# Altium schematic sheet Dev Board STM OLED 2.0.SchDoc("Dev Board STM OLED 2.0")
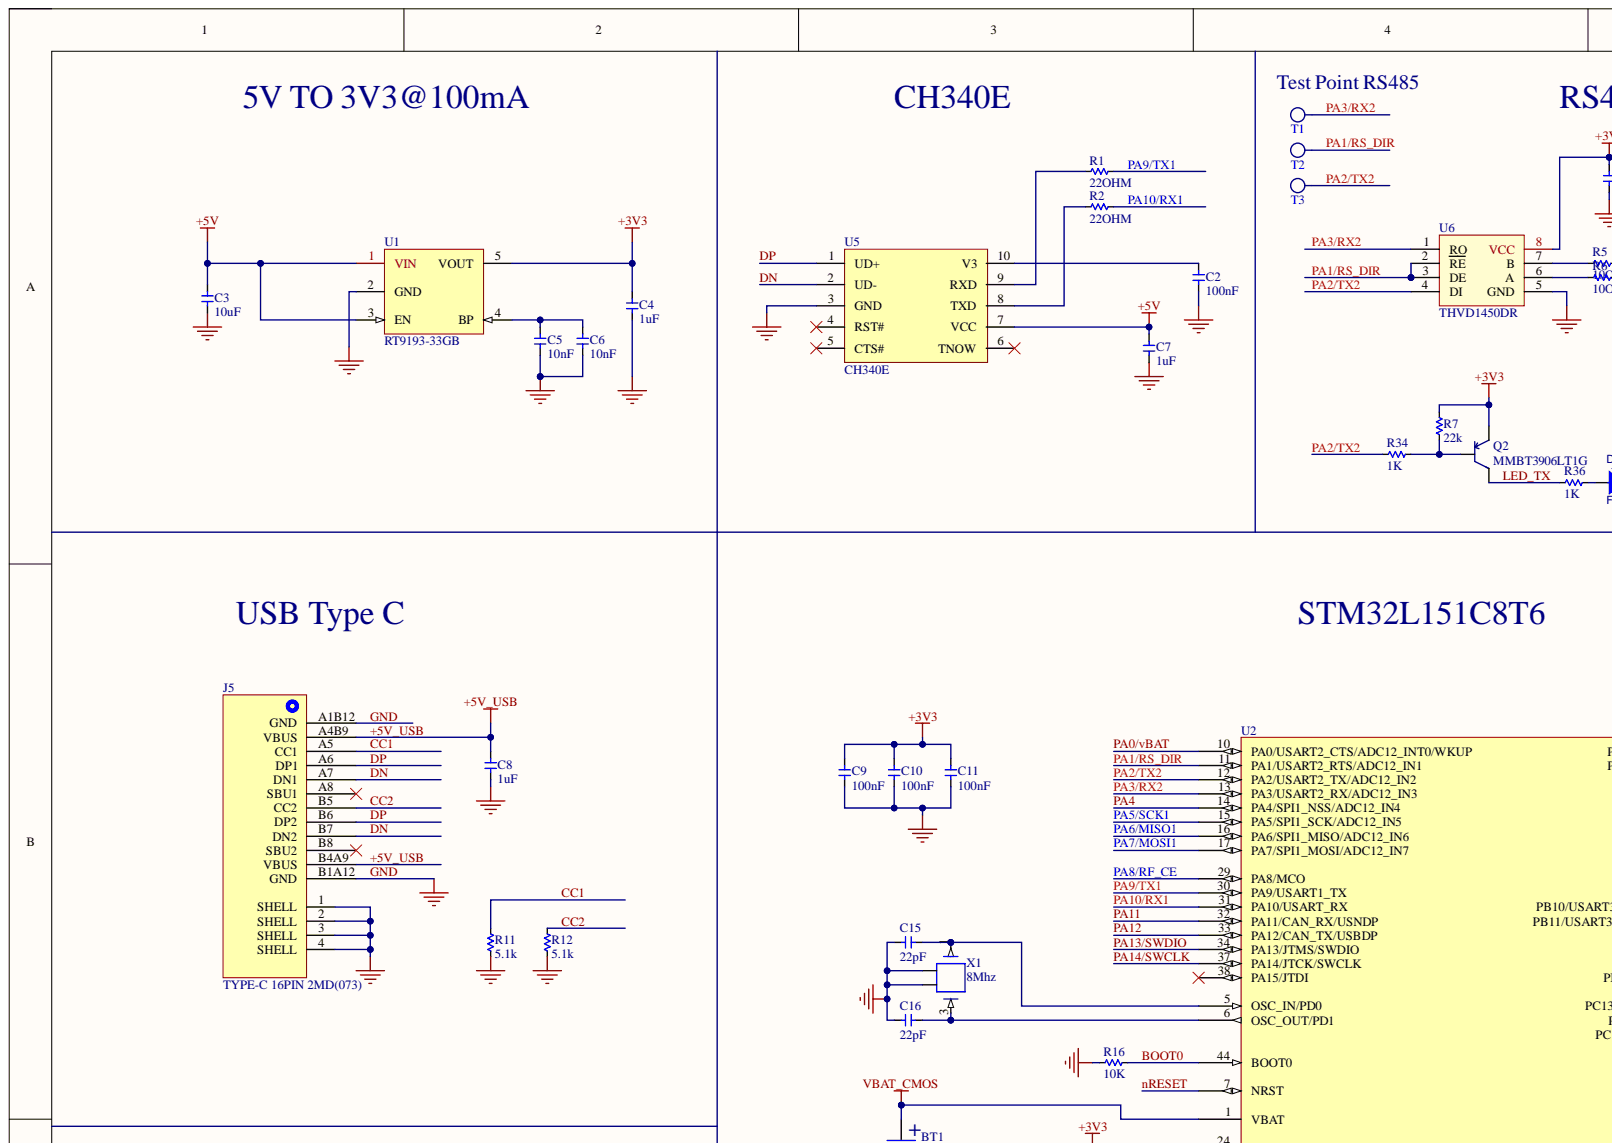
[diagram p0: Dev Board STM OLED 2.0.SchDoc, top left]
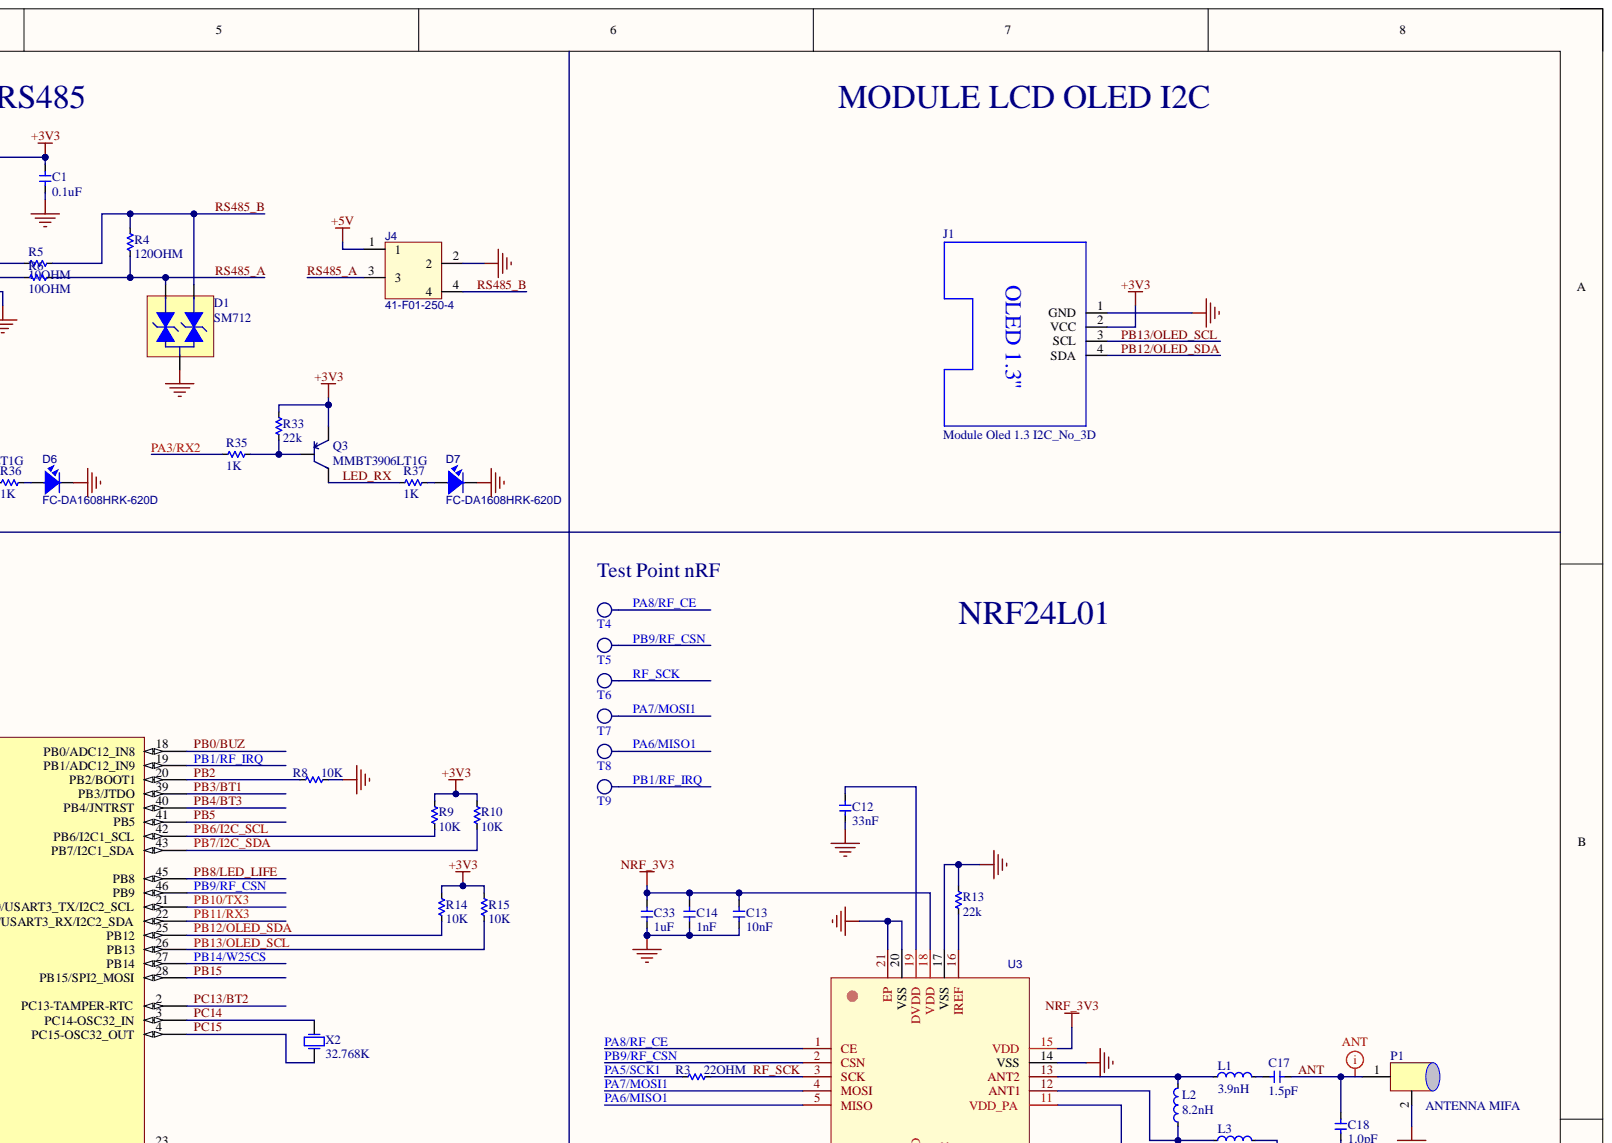
[diagram p1: Dev Board STM OLED 2.0.SchDoc, top right]
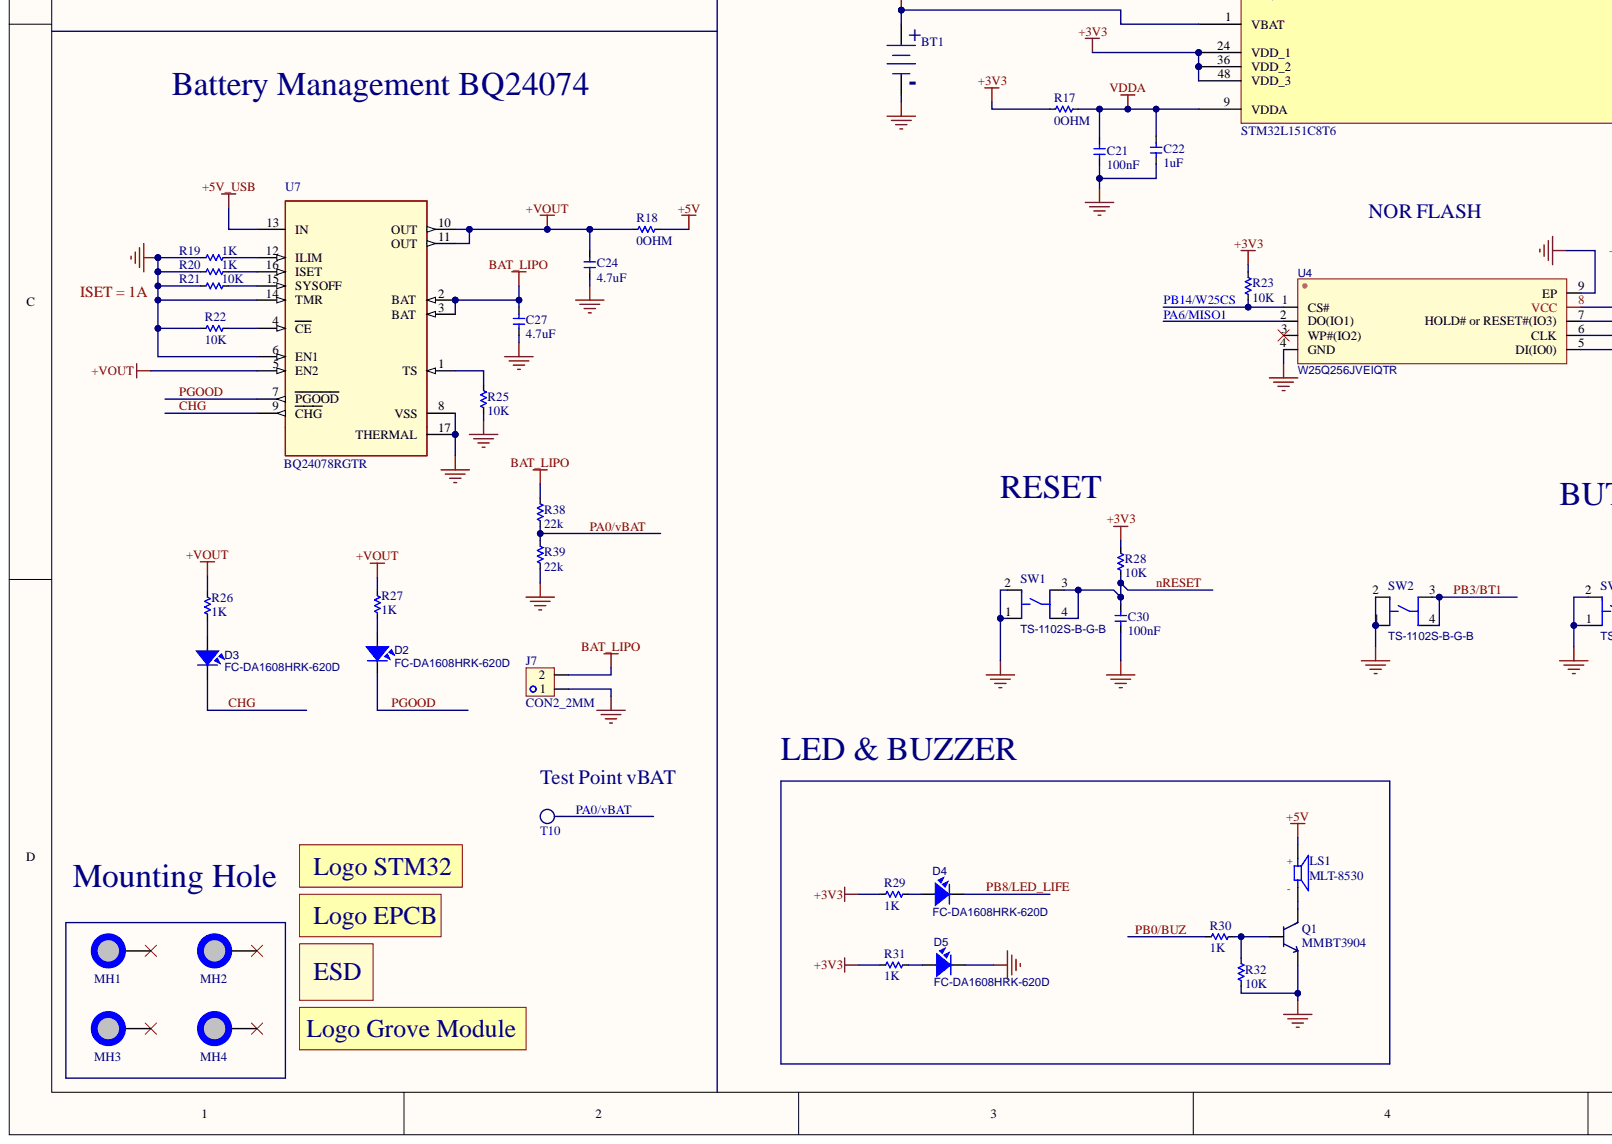
[diagram p2: Dev Board STM OLED 2.0.SchDoc, bottom left]
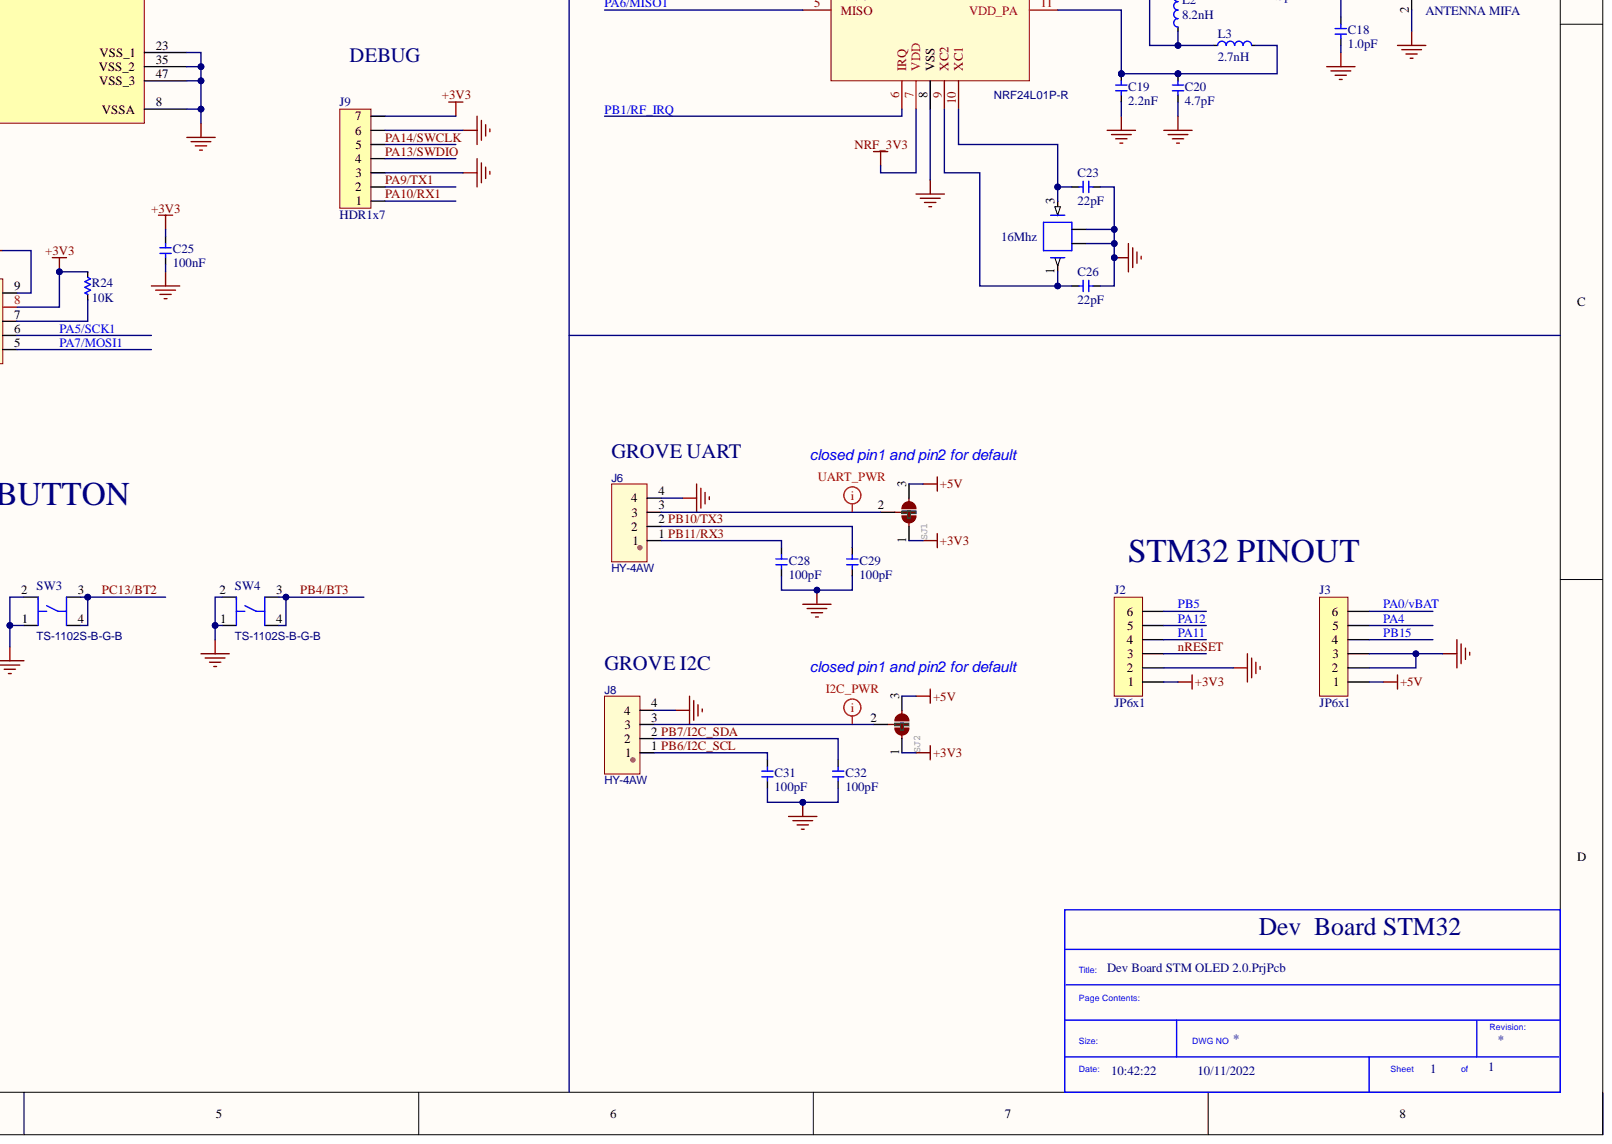
[diagram p3: Dev Board STM OLED 2.0.SchDoc, bottom right]

## Parts
BT1  CR1220-2ZX  [BAT_CR1220_SMD]  (value not drawn)
C1  0.1uF  [C_0603]
C2  100nF  [C_0402]
C3  10uF  [C_0805]
C4  1uF  [C_0603]
C5  10nF  [C_0402]
C6  10nF  [C_0402]
C7  1uF  [C_0603]
C8  1uF  [C_0603]
C9  100nF  [C_0402]
C10  100nF  [C_0402]
C11  100nF  [C_0402]
C12  33nF  [C_0402]
C13  10nF  [C_0402]
C14  1nF  [C_0402]
C15  22pF  [C_0402]
C16  22pF  [C_0402]
C17  1.5pF  [C_0402]
C18  1.0pF  [C_0402]
C19  2.2nF  [C_0402]
C20  4.7pF  [C_0402]
C21  100nF  [C_0402]
C22  1uF  [C_0603]
C23  22pF  [C_0402]
C24  4.7uF  [C_0603]
C25  100nF  [C_0402]
C26  22pF  [C_0402]
C27  4.7uF  [C_0603]
C28  100pF  [C_0402]
C29  100pF  [C_0402]
C30  100nF  [C_0402]
C31  100pF  [C_0402]
C32  100pF  [C_0402]
C33  1uF  [C_0603]
D1  SM712  [SOT-23-3_L2.9-W1.6-P1.90-LS2.8-BR]
D2  FC-DA1608HRK-620D  [LED0603-RD_RED]
D3  FC-DA1608HRK-620D  [LED0603-RD_RED]
D4  FC-DA1608HRK-620D  [LED0603-RD_RED]
D5  FC-DA1608HRK-620D  [LED0603-RD_RED]
D6  FC-DA1608HRK-620D  [LED0603-RD_RED]
D7  FC-DA1608HRK-620D  [LED0603-RD_RED]
ESD1  ESD  [LOGO ESD]  (no BOM)
J1  Module Oled 1.3 I2C_No_3D  [Module Oled 1.3 - No 3D]
J2  JP6x1  [JP6-D1T]  (no BOM)
J3  JP6x1  [JP6-D1T]  (no BOM)
J4  41-F01-250-4  [CONN-TH_41-F01-250-4]
J5  TYPE-C 16PIN 2MD(073)  [USB-C-SMD_TYPE-C16PIN]
J6  HY-4AW  [CONN-TH_4P-P2.00_HY-4AW]
J7  CON2_2MM  [CONN-TH_2P-P2.00_X2016WV-02K-N0SN]
J8  HY-4AW  [CONN-TH_4P-P2.00_HY-4AW]
J9  HDR1x7  [HDR1X7H]
L1  3.9nH  [L_0402]
L2  8.2nH  [L_0402]
L3  2.7nH  [L_0402]
LO1  Logo STM32  [LOGO STM32]  (no BOM)
LO2  LOGO EPCB  [LOGO EPCB TopLayer]  (value not drawn)  (no BOM)
LO3  Logo Grove Module  [Logo Grove Module]  (no BOM)
LS1  MLT-8530  [BUZ-SMD_4P-L8.5-W8.5-P8.50-BR]
MH1  MH_6.0MMC_3.2MMd_No Cu  [MH_6.0MMD_3.2MMD - No Cu]  (value not drawn)  (no BOM)
MH2  MH_6.0MMC_3.2MMd_No Cu  [MH_6.0MMD_3.2MMD - No Cu]  (value not drawn)  (no BOM)
MH3  MH_6.0MMC_3.2MMd_No Cu  [MH_6.0MMD_3.2MMD - No Cu]  (value not drawn)  (no BOM)
MH4  MH_6.0MMC_3.2MMd_No Cu  [MH_6.0MMD_3.2MMD - No Cu]  (value not drawn)  (no BOM)
P1  ANTENNA MIFA  [ANTENNA MIFA]  (no BOM)
Q1  MMBT3904  [SOT-23-3_L2.9-W1.3-P1.90-LS2.4-BR]
Q2  MMBT3906LT1G  [SOT-23-3_L2.9-W1.6-P1.90-LS2.8-BR]
Q3  MMBT3906LT1G  [SOT-23-3_L2.9-W1.6-P1.90-LS2.8-BR]
R1  22OHM  [R_0402]
R2  22OHM  [R_0402]
R3  22OHM  [R_0402]
R4  120OHM  [R_0603]
R5  10OHM  [R_0805]
R6  10OHM  [R_0805]
R7  22k  [R_0402]
R8  10K  [R_0402]
R9  10K  [R_0402]
R10  10K  [R_0402]
R11  5.1k  [R_0402]
R12  5.1k  [R_0402]
R13  22k  [R_0402]
R14  10K  [R_0402]
R15  10K  [R_0402]
R16  10K  [R_0402]
R17  0OHM  [R_0603]
R18  0OHM  [R_0603]
R19  1K  [R_0402]
R20  1K  [R_0402]
R21  10K  [R_0402]
R22  10K  [R_0402]
R23  10K  [R_0402]
R24  10K  [R_0402]
R25  10K  [R_0402]
R26  1K  [R_0402]
R27  1K  [R_0402]
R28  10K  [R_0402]
R29  1K  [R_0402]
R30  1K  [R_0402]
R31  1K  [R_0402]
R32  10K  [R_0402]
R33  22k  [R_0402]
R34  1K  [R_0402]
R35  1K  [R_0402]
R36  1K  [R_0402]
R37  1K  [R_0402]
R38  22k  [R_0402]
R39  22k  [R_0402]
SJ1  SOLDERJUMPER_2WAY  [SOLDERJUMPER_2WAY]  (value not drawn)  (no BOM)
SJ2  SOLDERJUMPER_2WAY  [SOLDERJUMPER_2WAY]  (value not drawn)  (no BOM)
SW1  TS-1102S-B-G-B  [SW-SMD_4P-L6.0-W6.4-P4.50-LS8.6]
SW2  TS-1102S-B-G-B  [SW-SMD_4P-L6.0-W6.4-P4.50-LS8.6]
SW3  TS-1102S-B-G-B  [SW-SMD_4P-L6.0-W6.4-P4.50-LS8.6]
SW4  TS-1102S-B-G-B  [SW-SMD_4P-L6.0-W6.4-P4.50-LS8.6]
T1  TEST-POINT'4545'  [TP-D45MIL]  (value not drawn)  (no BOM)
T2  TEST-POINT'4545'  [TP-D45MIL]  (value not drawn)  (no BOM)
T3  TEST-POINT'4545'  [TP-D45MIL]  (value not drawn)  (no BOM)
T4  TEST-POINT'4545'  [TP-D45MIL]  (value not drawn)  (no BOM)
T5  TEST-POINT'4545'  [TP-D45MIL]  (value not drawn)  (no BOM)
T6  TEST-POINT'4545'  [TP-D45MIL]  (value not drawn)  (no BOM)
T7  TEST-POINT'4545'  [TP-D45MIL]  (value not drawn)  (no BOM)
T8  TEST-POINT'4545'  [TP-D45MIL]  (value not drawn)  (no BOM)
T9  TEST-POINT'4545'  [TP-D45MIL]  (value not drawn)  (no BOM)
T10  TEST-POINT'4545'  [TP-D45MIL]  (value not drawn)  (no BOM)
U1  RT9193-33GB  [SOT-23-5_L3.0-W1.7-P0.95-LS2.8-BR]
U2  STM32L151C8T6  [LQFP-48_L7.0-W7.0-P0.50-LS9.0-BL]
U3  NRF24L01P-R  [QFN-20_L4.0-W4.0-P0.50-BL-EP]
U4  W25Q256JVEIQTR  [WSON-8_L8.0-W6.10-P1.27-BL-EP]
U5  CH340E  [MSOP-10_L3.0-W3.0-P0.50-LS5.0-BL]
U6  THVD1450DR  [SOIC-8_3.9x4.9x1.27P]
U7  BQ24078RGTR  [QFN16_3MM]
X1  8Mhz  [SMD-3225_4P]
X2  32.768K  [SMD-3215_2P]
X3  16Mhz  [SMD-3225_4P]

## Nets
+3V3: C1.2 C4.2 C9.2 C10.2 C11.2 C25.1 J1.2(VCC) J2.1 J9.7 Q2.2 Q3.2 R7.1 R9.1 R10.1 R14.1 R15.1 R17.2 R23.1 R24.1 R28.1 R29.1 R31.1 R33.1 SJ1.1 SJ2.1 U1.5(VOUT) U2.24(VDD_1) U2.36(VDD_2) U2.48(VDD_3) U4.8(VCC) U6.8(VCC)
+5V: C3.2 C7.2 J3.1 J4.1 LS1.1 R18.1 SJ1.3 SJ2.3 U1.1(VIN) U1.3(EN) U5.7(VCC)
+VOUT: C24.2 R18.2 R26.1 R27.1 U7.5(EN2) U7.10(OUT) U7.11(OUT)
BAT_LIPO: C27.2 J7.2 R38.1 U7.2(BAT) U7.3(BAT)
NRF_3V3: C13.2 C14.1 C33.2 U3.7(VDD) U3.15(VDD) U3.18(VDD)
VBAT_CMOS: BT1.1 U2.1(VBAT)
VDDA: C21.2 C22.2 R17.1 U2.9(VDDA)
+5V_USB: C8.2 J5.A4B9(VBUS) J5.B4A9(VBUS) U7.13(IN)
ANT: C17.2 C18.2 P1.1
BOOT0: R16.2 U2.44(BOOT0)
CC1: J5.A5(CC1) R11.2
CC2: J5.B5(CC2) R12.2
CHG: D3.1 U7.9(CHG)
DN: J5.A7(DN1) J5.B7(DN2) U5.2(UD-)
DP: J5.A6(DP1) J5.B6(DP2) U5.1(UD+)
GND: BT1.2 C1.1 C2.1 C3.1 C4.1 C5.1 C6.1 C7.1 C8.1 C9.1 C10.1 C11.1 C12.1 C13.1 C14.2 C15.2 C16.2 C18.1 C19.1 C20.1 C21.1 C22.1 C23.1 C24.1 C25.2 C26.1 C27.1 C28.1 C29.2 C30.1 C31.1 C32.1 C33.1 D1.3 D5.1 D6.1 D7.1 J1.1(GND) J2.2 J3.2 J3.3 J4.2 J5.1(SHELL) J5.2(SHELL) J5.3(SHELL) J5.4(SHELL) J5.A1B12(GND) J5.B1A12(GND) J6.4 J7.1 J8.4 J9.3 J9.6 P1.2 Q1.2 R8.1 R11.1 R12.1 R13.1 R16.1 R19.2 R20.1 R21.1 R22.1 R25.2 R32.2 R39.2 SW1.1 SW1.2 SW2.1 SW2.2 SW3.1 SW3.2 SW4.1 SW4.2 U1.2(GND) U2.8(VSSA) U2.23(VSS_1) U2.35(VSS_2) U2.47(VSS_3) U3.8(VSS) U3.14(VSS) U3.17(VSS) U3.20(VSS) U3.21(EP) U4.4(GND) U4.9(EP) U5.3(GND) U6.5(GND) U7.6(EN1) U7.8(VSS) U7.14(TMR) U7.17(THERMAL) X1.2 X1.4 X3.2 X3.4
LED_RX: Q3.3 R37.2
LED_TX: Q2.3 R36.2
nRESET: C30.2 J2.3 R28.2 SW1.3 SW1.4 U2.7(NRST)
PA0/vBAT: J3.6 R38.2 R39.1 T10.T U2.10(PA0/USART2_CTS/ADC12_INT0/WKUP)
PA1/RS_DIR: T2.T U2.11(PA1/USART2_RTS/ADC12_IN1) U6.2(RE) U6.3(DE)
PA2/TX2: R34.2 T3.T U2.12(PA2/USART2_TX/ADC12_IN2) U6.4(DI)
PA3/RX2: R35.2 T1.T U2.13(PA3/USART2_RX/ADC12_IN3) U6.1(RO)
PA4: J3.5 U2.14(PA4/SPI1_NSS/ADC12_IN4)
PA5/SCK1: R3.2 U2.15(PA5/SPI1_SCK/ADC12_IN5) U4.6(CLK)
PA6/MISO1: T8.T U2.16(PA6/SPI1_MISO/ADC12_IN6) U3.5(MISO) U4.2(DO(IO1))
PA7/MOSI1: T7.T U2.17(PA7/SPI1_MOSI/ADC12_IN7) U3.4(MOSI) U4.5(DI(IO0))
PA8/RF_CE: T4.T U2.29(PA8/MCO) U3.1(CE)
PA9/TX1: J9.2 R1.1 U2.30(PA9/USART1_TX)
PA10/RX1: J9.1 R2.1 U2.31(PA10/USART_RX)
PA11: J2.4 U2.32(PA11/CAN_RX/USNDP)
PA12: J2.5 U2.33(PA12/CAN_TX/USBDP)
PA13/SWDIO: J9.4 U2.34(PA13/JTMS/SWDIO)
PA14/SWCLK: J9.5 U2.37(PA14/JTCK/SWCLK)
PB0/BUZ: R30.1 U2.18(PB0/ADC12_IN8)
PB1/RF_IRQ: T9.T U2.19(PB1/ADC12_IN9) U3.6(IRQ)
PB2: R8.2 U2.20(PB2/BOOT1)
PB3/BT1: SW2.3 SW2.4 U2.39(PB3/JTDO)
PB4/BT3: SW4.3 SW4.4 U2.40(PB4/JNTRST)
PB5: J2.6 U2.41(PB5)
PB6/I2C_SCL: C31.2 J8.1 R9.2 U2.42(PB6/I2C1_SCL)
PB7/I2C_SDA: C32.2 J8.2 R10.2 U2.43(PB7/I2C1_SDA)
PB8/LED_LIFE: D4.1 U2.45(PB8)
PB9/RF_CSN: T5.T U2.46(PB9) U3.2(CSN)
PB10/TX3: C29.1 J6.2 U2.21(PB10/USART3_TX/I2C2_SCL)
PB11/RX3: C28.2 J6.1 U2.22(PB11/USART3_RX/I2C2_SDA)
PB12/OLED_SDA: J1.4(SDA) R14.2 U2.25(PB12)
PB13/OLED_SCL: J1.3(SCL) R15.2 U2.26(PB13)
PB14/W25CS: R23.2 U2.27(PB14) U4.1(CS#)
PB15: J3.4 U2.28(PB15/SPI2_MOSI)
PC13/BT2: SW3.3 SW3.4 U2.2(PC13-TAMPER-RTC)
PC14: U2.3(PC14-OSC32_IN) X2.2
PC15: U2.4(PC15-OSC32_OUT) X2.1
PGOOD: D2.1 U7.7(PGOOD)
RF_SCK: R3.1 T6.T U3.3(SCK)
RS485_A: D1.2 J4.3 R4.2 R6.1
RS485_B: D1.1 J4.4 R4.1 R5.1
NetC2_2: C2.2 U5.10(V3)
NetC5_2: C5.2 C6.2 U1.4(BP)
NetC12_2: C12.2 U3.19(DVDD)
NetC15_1: C15.1 U2.5(OSC_IN/PD0) X1.1
NetC16_1: C16.1 U2.6(OSC_OUT/PD1) X1.3
NetC17_1: C17.1 L1.2
NetC19_2: C19.2 C20.2 L3.2 U3.11(VDD_PA)
NetC23_2: C23.2 U3.10(XC1) X3.3
NetC26_2: C26.2 U3.9(XC2) X3.1
NetD2_2: D2.2 R27.2
NetD3_2: D3.2 R26.2
NetD4_2: D4.2 R29.2
NetD5_2: D5.2 R31.2
NetD6_2: D6.2 R36.1
NetD7_2: D7.2 R37.1
NetJ6_3: J6.3 SJ1.2
NetJ8_3: J8.3 SJ2.2
NetL1_1: L1.1 L2.2 U3.13(ANT2)
NetL2_1: L2.1 L3.1 U3.12(ANT1)
NetLS1_2: LS1.2 Q1.3
NetQ1_1: Q1.1 R30.2 R32.1
NetQ2_1: Q2.1 R7.2 R34.1
NetQ3_1: Q3.1 R33.2 R35.1
NetR1_2: R1.2 U5.9(RXD)
NetR2_2: R2.2 U5.8(TXD)
NetR5_2: R5.2 U6.7(B)
NetR6_2: R6.2 U6.6(A)
NetR13_2: R13.2 U3.16(IREF)
NetR19_1: R19.1 U7.12(ILIM)
NetR20_2: R20.2 U7.16(ISET)
NetR21_2: R21.2 U7.15(SYSOFF)
NetR22_2: R22.2 U7.4(CE)
NetR24_2: R24.2 U4.7(HOLD#_or_RESET#(IO3))
NetR25_1: R25.1 U7.1(TS)

Not connected: J5 A8(SBU1) B8(SBU2); MH1 1; MH2 1; MH3 1; MH4 1; U2 38(PA15/JTDI); U4 3(WP#(IO2)); U5 4(RST#) 5(CTS#) 6(TNOW)

## Library data of the parts
BT1 = CR1220-2ZX: SMD Battery Holders, Clips & Contacts RoHS 1220 batteries; Manufacturer: Q&J; Assembly Type: SMT Assembly; Component Kind: Standard; ComponentLink1Description: https://datasheet.ciiva.com/16220/m60-2p2-16220480.pdf?src-supplier=Future+Electronics; ComponentLink1URL: https://datasheet.ciiva.com/16220/m60-2p2-16220480.pdf?src-supplier=Future+Electronics; ComponentLink2Description: https://datasheet.ciiva.com/18491/1056-18491289.pdf?src-supplier=Digi-Key; ComponentLink2URL: https://datasheet.ciiva.com/18491/1056-18491289.pdf?src-supplier=Digi-Key; ComponentLink3Description: https://datasheet.ciiva.com/26923/getdatasheetpartid-755844-26923850.pdf?src-supplier=Verical; ComponentLink3URL: https://datasheet.ciiva.com/26923/getdatasheetpartid-755844-26923850.pdf?src-supplier=Verical; LCSC Part Number: C969906; Library Name: Dev Board STM OLED 2.0.SCHLIB; Manufacturer Part Number: CR1220-2ZX; Package: SMD
C1 = CC0603KRX7R9BB104: 100NF 50V 0603 ROHS; Manufacturer: YAGEO; Assembly Type: SMT Assembly; Component Kind: Standard; ComponentLink1Description: Digikey Link; ComponentLink1URL: https://www.digikey.com/en/products/detail/yageo/CC0603KRX7R9BB104/2103082?s=N4IgTCBcDaIMwEYEFoFwCzuWZA5AIiALoC%2BQA; ComponentLink2Description: LCSC Link; ComponentLink2URL: https://jlcpcb.com/parts/componentSearch?searchTxt=10k%200603; LCSC Part Number: C14663; Library Name: Dev Board STM OLED 2.0.SCHLIB; Manufacturer Part Number: CC0603KRX7R9BB104; Package: 0603
C2 C9-C11 C21 C25 C30 = 0402B104K160NT: 100nF 16V X7R ±10% 0402 Multilayer Ceramic Capacitors MLCC - SMD/SMT ROH; Manufacturer: FH(Guangdong Fenghua Advanced Tech); Assembly Type: SMT Assembly; Component Kind: Standard; ComponentLink1Description: Digikey Link; ComponentLink2Description: LCSC Link; ComponentLink2URL: https://jlcpcb.com/parts/componentSearch?searchTxt=10k%200603; LCSC Part Number: C41851; Library Name: Dev Board STM OLED 2.0.SCHLIB; Manufacturer Part Number: 0402B104K160NT; Package: 0402
C3 = CL21A106KAYNNNE: 10UF 25V 0805 ROHS; Manufacturer: Samsung Electro-Mechanics; Assembly Type: SMT Assembly; Component Kind: Standard; ComponentLink1Description: LCSC Link; ComponentLink1URL: https://lcsc.com/product-detail/Multilayer-Ceramic-Capacitors-MLCC-SMD-SMT_SAMSUNG_CL21A106KAYNNNE_10uF-106-10-25V_C15850.html/?href=jlc-SMT; LCSC Part Number: C15850; Library Name: Dev Board STM OLED 2.0.SCHLIB; Manufacturer Part Number: CL21A106KAYNNNE; Package: 0805
C4 C7 C8 C22 C33 = CL10A105KB8NNNC: 1UF 50V 0603 ROHS; Manufacturer: Samsung Electro-Mechanics; Assembly Type: SMT Assembly; Component Kind: Standard; ComponentLink1Description: Digikey Link; ComponentLink1URL: https://www.digikey.com/en/products/detail/samsung-electro-mechanics/CL10A105KB8NNNC/3887518?s=N4IgTCBcDaIMIBkCMAGAgqgrAaQEIA4A5YuEAXQF8g; ComponentLink2Description: LCSC Link; ComponentLink2URL: https://jlcpcb.com/parts/componentSearch?searchTxt=10k%200603; LCSC Part Number: C15849; Library Name: Dev Board STM OLED 2.0.SCHLIB; Manufacturer Part Number: CL10A105KB8NNNC; Package: 0603
C5 C6 C13 = 0402B103K500NT: 10nF 50V X7R ±10% 0402 Multilayer Ceramic Capacitors MLCC - SMD/SMT ROH; Manufacturer: FH(Guangdong Fenghua Advanced Tech); Manufacturer Part Number: 0402CG120J500NT; Assembly Type: SMT Assembly; Component Kind: Standard; ComponentLink1Description: Digikey Link; ComponentLink2Description: LCSC Link; ComponentLink2URL: https://jlcpcb.com/parts/componentSearch?searchTxt=10k%200603; LCSC Part Number: C1547; Library Name: Dev Board STM OLED 2.0.SchLib; Package: 0402
C12 = CC0402KRX7R9BB333: 50V 33nF X7R ±10% 0402 Multilayer Ceramic Capacitors MLCC - SMD/SMT ROHS; Manufacturer: YAGEO; Assembly Type: SMT Assembly; Component Kind: Standard; ComponentLink1Description: Digikey Link; ComponentLink2Description: LCSC Link; ComponentLink2URL: https://jlcpcb.com/parts/componentSearch?searchTxt=10k%200603; LCSC Part Number: C106862; Library Name: Dev Board STM OLED 2.0.SCHLIB; Manufacturer Part Number: CC0402KRX7R9BB333; Package: 0402
C14 = 0402B102K500NT: 1nF 50V X7R ±10% 0402 Multilayer Ceramic Capacitors MLCC - SMD/SMT ROHS; Manufacturer: FH(Guangdong Fenghua Advanced Tech); Assembly Type: SMT Assembly; Component Kind: Standard; ComponentLink1Description: Digikey Link; ComponentLink2Description: LCSC Link; ComponentLink2URL: https://jlcpcb.com/parts/componentSearch?searchTxt=10k%200603; LCSC Part Number: C1523; Library Name: Dev Board STM OLED 2.0.SchLib; Manufacturer Part Number: 0402B102K500NT; Package: 0402
C15 C16 C23 C26 = 0402CG220J500NT: 22pF 50V C0G ±5% 0402 Multilayer Ceramic Capacitors MLCC - SMD/SMT ROHS; Manufacturer: FH(Guangdong Fenghua Advanced Tech); Assembly Type: SMT Assembly; Component Kind: Standard; ComponentLink1Description: Digikey Link; ComponentLink2Description: LCSC Link; ComponentLink2URL: https://jlcpcb.com/parts/componentSearch?searchTxt=10k%200603; LCSC Part Number: C1555; Library Name: Dev Board STM OLED 2.0.SCHLIB; Manufacturer Part Number: 0402CG220J500NT; Package: 0402
C17 = 0402CG1R5C500NT: 1.5pF 50V C0G ±0.25pF 0402 Multilayer Ceramic Capacitors MLCC -; Manufacturer: FH(Guangdong Fenghua Advanced Tech); Assembly Type: SMT Assembly; Component Kind: Standard; ComponentLink1Description: Digikey Link; ComponentLink2Description: LCSC Link; ComponentLink2URL: https://jlcpcb.com/parts/componentSearch?searchTxt=10k%200603; LCSC Part Number: C1552; Library Name: Dev Board STM OLED 2.0.SCHLIB; Manufacturer Part Number: 0402CG1R5C500NT; Package: 0402
C18 = 0402CG1R0C500NT: 1.0pF 50V C0G ±5% 0402 Multilayer Ceramic Capacitors MLCC - SMD/SMT ROHS; Manufacturer: FH(Guangdong Fenghua Advanced Tech); Assembly Type: SMT Assembly; Component Kind: Standard; ComponentLink1Description: Digikey Link; ComponentLink2Description: LCSC Link; ComponentLink2URL: https://jlcpcb.com/parts/componentSearch?searchTxt=10k%200603; LCSC Part Number: C1550; Library Name: Dev Board STM OLED 2.0.SchLib; Manufacturer Part Number: 0402CG1R0C500NT; Package: 0402
C19 = 0402B222K500NT: 2.2nF 50V C0G ±5% 0402 Multilayer Ceramic Capacitors MLCC - SMD/SMT ROHS; Manufacturer: FH(Guangdong Fenghua Advanced Tech); Assembly Type: SMT Assembly; Component Kind: Standard; ComponentLink1Description: Digikey Link; ComponentLink2Description: LCSC Link; ComponentLink2URL: https://jlcpcb.com/parts/componentSearch?searchTxt=10k%200603; LCSC Part Number: C1531; Library Name: Dev Board STM OLED 2.0.SchLib; Manufacturer Part Number: 0402B222K500NT; Package: 0402
C20 = 0402CG4R7C500NT: 4.7pF 50V C0G ±5% 0402 Multilayer Ceramic Capacitors MLCC - SMD/SMT ROHS; Manufacturer: FH(Guangdong Fenghua Advanced Tech); Assembly Type: SMT Assembly; Component Kind: Standard; ComponentLink1Description: Digikey Link; ComponentLink2Description: LCSC Link; ComponentLink2URL: https://jlcpcb.com/parts/componentSearch?searchTxt=10k%200603; LCSC Part Number: C1569; Library Name: Dev Board STM OLED 2.0.SCHLIB; Manufacturer Part Number: 0402CG4R7C500NT; Package: 0402
C24 C27 = CL10A475KO8NNNC: 4.7UF 16V 0603 ROHS; Manufacturer: Samsung Electro-Mechanics; Assembly Type: SMT Assembly; Component Kind: Standard; LCSC Part Number: C19666; Library Name: Dev Board STM OLED 2.0.SCHLIB; Manufacturer Part Number: CL10A475KO8NNNC; Package: 0603
C28 C29 C31 C32 = 0402CG101J500NT: 100pF 50V C0G ±5% 0402 Multilayer Ceramic Capacitors MLCC - SMD/SMT ROHS; Manufacturer: FH(Guangdong Fenghua Advanced Tech); Assembly Type: SMT Assembly; Component Kind: Standard; ComponentLink1Description: Digikey Link; ComponentLink2Description: LCSC Link; ComponentLink2URL: https://jlcpcb.com/parts/componentSearch?searchTxt=10k%200603; LCSC Part Number: C1546; Library Name: Dev Board STM OLED 2.0.SCHLIB; Manufacturer Part Number: 0402CG101J500NT; Package: 0402
D1 = SM712-TP: TVS DIODE 12V 21V SOT23; Manufacturer: MDD（Microdiode Electronics）; Assembly Type: SMT Assembly; Component Kind: Standard; ComponentLink1Description: http://www.mccsemi.com/up_pdf/SM712(SOT-23).pdf?src-supplier=Digi-Key; ComponentLink1URL: http://www.mccsemi.com/up_pdf/SM712(SOT-23).pdf?src-supplier=Digi-Key; ComponentLink2Description: http://www.mccsemi.com/up_pdf/SM712(SOT-23).pdf?src-supplier=Future+Electronics; ComponentLink2URL: http://www.mccsemi.com/up_pdf/SM712(SOT-23).pdf?src-supplier=Future+Electronics; ComponentLink3Description: http://61.222.192.61/mccsemi/up_pdf/SM712(SOT-23).pdf?src-supplier=Mouser; ComponentLink3URL: http://61.222.192.61/mccsemi/up_pdf/SM712(SOT-23).pdf?src-supplier=Mouser; LCSC Part Number: C502564; Library Name: Dev Board STM OLED 2.0.SCHLIB; Manufacturer Part Number: SM712; Package: SOT-23-3_L2.9-W1.6-P1.90-LS2.8-BR
D2-D7 = FC-DA1608HRK-620D: LED Red 0603 Light Emitting Diodes (LED) ROHS; Manufacturer: NATIONSTAR; Assembly Type: SMT Assembly; Component Kind: Standard; LCSC Part Number: C84263; Library Name: Dev Board STM OLED 2.0.SCHLIB; Manufacturer Part Number: FC-DA1608HRK-620D; Package: LED_0603
ESD1 = ESD: lOGO ESD; Library Name: Dev Board STM OLED 2.0.SchLib
J1 = Module Oled 1.3 I2C_No_3D: Module Oled 1.3 I2C; Manufacturer: EPCB.vn; Assembly Type: Hand Soldering; Component Kind: Standard; LCSC Part Number: N/A; Library Name: Dev Board STM OLED 2.0.SCHLIB; Manufacturer Part Number: N/A; Package: Through P=2.54
J2 J3 = JP 6X1 2.54: WR-PHD 2.54 mm Dual Socket Header; Manufacturer: W¨¹rth Elektronik eiSos GmbH; partNo: 61301621821; ComponentLink1 Description: Manufacturer; ComponentLink2 Description: Datasheet; NoPins: 16; ComponentLink1URL: http://www.we-online.com; ComponentLink2URL: http://katalog.we-online.de/em/datasheet/6130xx21821.pdf; Created Date: 29 March 2013; Library Name: Dev Board STM OLED 2.0.SCHLIB; Created by: Karrer Zheng
J4 = Terminal 41-F01-250-4: Terminal Block  4 0.138"（3.50mm） 24~16 0.5~0.75 P=3.5mm Spring Clamp System Terminal Block RoHS; Manufacturer: HIWA; Assembly Type: Hand Soldering; Component Kind: Standard; LCSC Part Number: C173392; Library Name: Dev Board STM OLED 2.0.SCHLIB; Manufacturer Part Number: 41-F01-250-4; Package: Through hole
J5 = TYPE-C 16PIN 2MD(073): 5A 1 Surface Mount 16 Female -25℃~+85℃ Type-C SMD USB Connectors ROHS; Manufacturer: SHOU HAN; Assembly Type: SMT Assembly; Component Kind: Standard; LCSC Part Number: C2765186; Library Name: Dev Board STM OLED 2.0.SCHLIB; Manufacturer Part Number: TYPE-C16PIN; Package: SMD
J6 J8 = HY-4AW: HY-4AW 1x4P 1 2mm 4 Push-Pull,P=2mm Wire To Board / Wire To Wire Connector ROHS; Manufacturer: BOOMELE; Pakage: 弯插,P=2mm; Assembly Type: Hand Soldering; Component Kind: Standard; LCSC Part Number: C146068; Library Name: Dev Board STM OLED 2.0.SchLib; Manufacturer Part Number: HY-4AW
J7 = Con2_2.0MM: PH Header Male Pin 0.079"(2.00mm) 2 Through Hole,P=2.0mm Wire To Board / Wire To Wire Connector RoHS; Manufacturer: XKB Connectivity; Manufacturer Part Number: X2016WV-02K-N0SN; Assembly Type: Hand Soldering; Component Kind: Standard; LCSC Part Number: C388730; Library Name: Dev Board STM OLED 2.0.SCHLIB; Pakage: Through Hole,P=2.0mm
J9 = Header 1x7: Header IDC, 1x8 Pin horizontal 2.54mm Pitch (Non-Boxed, Male); Manufacturer Part Number: HDR1x7 Horizonal; ComponentLink1 Description: Manufacturer; ComponentLink2 Description: Datasheet; Assembly Type: Hand Soldering; Component Kind: Standard; ComponentLink1URL: http://www.we-online.com; ComponentLink2URL: http://katalog.we-online.de/em/datasheet/6130xx143121.pdf; Library Name: Dev Board STM OLED 2.0.SCHLIB; Package: P=2.54
L1 = FHW0402UC3N9JST: 3.9nH 840mA ±5% 66mΩ 0402 Inductors (SMD) ROHS; Manufacturer: FH(Guangdong Fenghua Advanced Tech); Assembly Type: SMT Assembly; Component Kind: Standard; LCSC Part Number: C317939; Library Name: Dev Board STM OLED 2.0.SCHLIB; Manufacturer Part Number: FHW0402UC3N9JST; Package: 0402
L2 = FHW0402UC8N2JST: 8.2nH 680mA ±5% 100mΩ 0402 Inductors (SMD) ROHS; Manufacturer: FH(Guangdong Fenghua Advanced Tech); Assembly Type: SMT Assembly; Component Kind: Standard; LCSC Part Number: C317946; Library Name: Dev Board STM OLED 2.0.SCHLIB; Manufacturer Part Number: FHW0402UC8N2JST; Package: 0402
L3 = FHW0402UC2N7KST: 2.7nH 640mA  ±10% 120mΩ 0402 Inductors (SMD) ROHS; Manufacturer: FH(Guangdong Fenghua Advanced Tech); Assembly Type: SMT Assembly; Component Kind: Standard; LCSC Part Number: C317935; Library Name: Dev Board STM OLED 2.0.SchLib; Manufacturer Part Number: FHW0402UC2N7KST; Package: 0402
LS1 = BUZ_MLT-8530: BUZZERS MAGNETIC NO 2700HZ 3.6V 2.5 ~ 4.5V 8.5MM X 8.5MM 8.5*8.5*3MM ROHS; Manufacturer: Jiangsu Huaneng Elec; Assembly Type: SMT Assembly; Component Kind: Standard; LCSC Part Number: C94599; Library Name: Dev Board STM OLED 2.0.SCHLIB; Manufacturer Part Number: MLT-8530; Package: SMD-4_8.7x8.7x3.0
MH1-MH4 = MH_6.0MMC_3.2MMd_No Cu: Mounting Hole 6.0mm Diameter, 3.2mm Drill; .Creator: MM; Library Name: Dev Board STM OLED 2.0.SchLib
P1 = ANTENNA MIFA: ANTENNA IFA PCB; Library Name: Dev Board STM OLED 2.0.SCHLIB
Q1 = MMBT3904: MMBT3904 TRANSISTORS (NPN/PNP) NPN 200MA 40V SOT-23(SOT-23-3) ROHS; Manufacturer: Changjiang Electronics Tech (CJ); Assembly Type: SMT Assembly; Component Kind: Standard; LCSC Part Number: C20526; Library Name: Dev Board STM OLED 2.0.SCHLIB; Manufacturer Part Number: MMBT3904; Package: SOT-23-3
Q2 Q3 = MMBT3906LT1G: MMBT3906 TRANSISTORS (NPN/PNP) PNP 200MA 40V SOT-23(SOT-23-3) ROHS; Manufacturer: ON Semicon; Assembly Type: SMT Assembly; Component Kind: Standard; LCSC Part Number: C53444; Library Name: Dev Board STM OLED 2.0.SchLib; Manufacturer Part Number: MMBT3906LT1G; Package: SOT-23-3
R1-R3 = 0402WGF220JTCE: 22Ω 0402 62.5mW Thick Film Resistors 50V ±100ppm/℃ ±1% -55℃~+155℃; Manufacturer: Uniroyal Elec; Assembly Type: SMT Assembly; Component Kind: Standard; LCSC Part Number: C25092; Library Name: Dev Board STM OLED 2.0.SCHLIB; Manufacturer Part Number: 0402WGF220JTCE; Package: 0402
R4 = 0603WAF1200T5E: 120OHMS ±1% 1/10W 0603 ROHS; Manufacturer: Uniroyal Elec; Assembly Type: SMT Assembly; Component Kind: Standard; LCSC Part Number: C22787; Library Name: Dev Board STM OLED 2.0.SCHLIB; Manufacturer Part Number: 0603WAF1200T5E; Package: 0603
R5 R6 = 0805W8F100JT5E: 10OHMS ±1% 1/8W 0805 ROHS; Manufacturer: Uniroyal Elec; Assembly Type: SMT Assembly; Component Kind: Standard; LCSC Part Number: C17415; Library Name: Dev Board STM OLED 2.0.SCHLIB; Manufacturer Part Number: 0805W8F100JT5E; Package: 0805
R7 R13 R33 R38 R39 = 0402WGF2202TCE: 22k 0402 62.5mW Thick Film Resistors 50V ±100ppm/℃ ±1% -55℃~+155℃ 22kΩ; Manufacturer: Uniroyal Elec; Assembly Type: SMT Assembly; Component Kind: Standard; LCSC Part Number: C25768; Library Name: Dev Board STM OLED 2.0.SchLib; Manufacturer Part Number: 0402WGF2202TCE; Package: 0402
R8-R10 R14-R16 R21-R25 R28 R32 = 0402WGF1002TCE: 10kΩ 0402 62.5mW Thick Film Resistors 50V ±100ppm/℃ ±1% -55℃~+155℃; Manufacturer: Uniroyal Elec; Assembly Type: SMT Assembly; Component Kind: Standard; LCSC Part Number: C25744; Library Name: Dev Board STM OLED 2.0.SchLib; Manufacturer Part Number: 0402WGF1002TCE; Package: 0402
R11 R12 = 0402WGJ0512TCE: 5.1KOHMS ±5% 1/16W 0402 ROHS; Manufacturer: Uniroyal Elec; Assembly Type: SMT Assembly; Component Kind: Standard; LCSC Part Number: C25941; Library Name: Dev Board STM OLED 2.0.SchLib; Manufacturer Part Number: 0402WGJ0512TCE; Package: 0402
R17 R18 = 0603WAF0000T5E: 0OHMS ±1% 1/10W 0603 ROHS; Manufacturer: Uniroyal Elec; Assembly Type: SMT Assembly; Component Kind: Standard; LCSC Part Number: C21189; Library Name: Dev Board STM OLED 2.0.SCHLIB; Manufacturer Part Number: 0603WAF0000T5E; Package: 0603
R19 R20 R26 R27 R29-R31 R34-R37 = 0402WGF1001TCE: 1kΩ 0402 62.5mW Thick Film Resistors 50V ±100ppm/℃ ±1% -55℃~+155℃; Manufacturer: Uniroyal Elec; Assembly Type: SMT Assembly; Component Kind: Standard; LCSC Part Number: C11702; Library Name: Dev Board STM OLED 2.0.SchLib; Manufacturer Part Number: 0402WGF1001TCE; Package: 0402
SJ1 SJ2 = SOLDERJUMPER_2WAY: 2-Way Solder Jumper; GateName_1: G$1; DeviceSetName: SOLDERJUMPER_2WAY; Library Name: Dev Board STM OLED 2.0.SCHLIB; LibraryName: microbuilder; SymbolName_1: SOLDERJUMPER_2WAY
SW1-SW4 = TS-1102S-B-G-B: Botton SMD TACTILE SWITCHES ROHS; Manufacturer: XKB Connectivity; Manufacturer Part Number: TS-1102S-C-F-B; Assembly Type: SMT Assembly; Component Kind: Standard; LCSC Part Number: C381064; Library Name: Dev Board STM OLED 2.0.SCHLIB; Package: SMD-4_6.0x6.0x4.5P-2
T1-T10 = TEST-POINT'4545': Manufacturer: No; Description: TEST-POINT'4545'; LCSC Part Number: No; Library Name: Dev Board STM OLED 2.0.SchLib; Manufacturer Part Number: No; Package: No
U1 = RT9193-33GB: 5.5V 300mV @ 300mA - - Fixed 3.3V SOT-23-5 Linear Voltage Regulators (LDO) ROHS; Manufacturer: Richtek Tech; Assembly Type: SMT Assembly; Component Kind: Standard; LCSC Part Number: C15651; Library Name: Dev Board STM OLED 2.0.SchLib; Manufacturer Part Number: RT9193-33GB; Package: SOT-23-5
U2 = STM32L151C8T6: STM32 ARM-based 32-bit MCU with 64 Kbytes Flash, 48-pin LQFP, Industrial Temperature; Manufacturer: STMicroelectronics; Assembly Type: SMT Assembly; Component Kind: Standard; ComponentLink1Description: Manufacturer Link; ComponentLink1URL: www.st.com/stm32; ComponentLink2Description: Datasheet; ComponentLink2URL: http://www.st.com/stonline/products/literature/ds/13587.pdf; ComponentLink3Description: https://datasheet.ciiva.com/3220/lm2734-3220071.pdf?src-supplier=Avnet; ComponentLink3URL: https://datasheet.ciiva.com/3220/lm2734-3220071.pdf?src-supplier=Avnet; ComponentLink4Description: https://datasheet.ciiva.com/3220/lm2734-3220072.pdf?src-supplier=Avnet; ComponentLink4URL: https://datasheet.ciiva.com/3220/lm2734-3220072.pdf?src-supplier=Avnet; ComponentLink5Description: https://datasheet.ciiva.com/6598/lm2734-6598009.pdf?src-supplier=Digi-Key; ComponentLink5URL: https://datasheet.ciiva.com/6598/lm2734-6598009.pdf?src-supplier=Digi-Key; ComponentLink6Description: https://datasheet.ciiva.com/16536/lm2734-16536154.pdf?src-supplier=Avnet+Asia; ComponentLink6URL: https://datasheet.ciiva.com/16536/lm2734-16536154.pdf?src-supplier=Avnet+Asia; ComponentLink7Description: New link; LCSC Part Number: C9861; Library Name: Dev Board STM OLED 2.0.SCHLIB; Manufacturer Part Number: STM32L151C8T6; Package: LQFP-48_7.0x7.0x0.5P Copy
U3 = NRF24L01P-R: NRF24L01P-R SPI QFN-20_4x4x05P RF Transceiver ICs ROHS; Manufacturer: NORDIC; Assembly Type: SMT Assembly; Component Kind: Standard; LCSC Part Number: C8791; Library Name: Dev Board STM OLED 2.0.SchLib; Manufacturer Part Number: NRF24L01P-R; Package: QFN-20_4x4x05P
U4 = W25Q256JVEIQTR: W25Q256 WSON-8_8x6mm NOR FLASH ROHS; Manufacturer: Winbond Elec; Assembly Type: SMT Assembly; Component Kind: Standard; LCSC Part Number: C97522; Library Name: Dev Board STM OLED 2.0.SCHLIB; Manufacturer Part Number: W25Q256JVEIQTR; Package: WSON-8_8x6mm
U5 = CH340E: Transceiver USB 2.0 2Mbps MSOP-10 USB ICs RoHS; Manufacturer: WCH(Jiangsu Qin Heng); Assembly Type: SMT Assembly; Component Kind: Standard; LCSC Part: C99652; Library Name: Dev Board STM OLED 2.0.SCHLIB; Manufacturer Part: CH340E; Package: MSOP-10
U6 = THVD1450DR: THVD1450DR transceiver 3V~5.5V 50Mbps 1/1 SOIC-8_150mil RS-485/RS-422 ICs ROHS; Manufacturer: Texas Instruments; Assembly Type: SMT Assembly; Component Kind: Standard; ComponentLink1Description: http://www.ti.com/lit/ds/symlink/sn65hvd1785.pdf?src-supplier=Digi-Key; ComponentLink1URL: http://www.ti.com/lit/ds/symlink/sn65hvd1785.pdf?src-supplier=Digi-Key; ComponentLink2Description: http://datasheet.iiic.cc/texas_instruments/SN65HVD1785DR.pdf?src-supplier=IIIC; ComponentLink2URL: http://datasheet.iiic.cc/texas_instruments/SN65HVD1785DR.pdf?src-supplier=IIIC; LCSC Part Number: C2671361; Library Name: Dev Board STM OLED 2.0.SchLib; Manufacturer Part Number: THVD1450DR; Package: SOIC-8_3.9x4.9x1.27P
U7 = BQ24078RGTR: VQFN-16 Battery Management ICs ROHS; Manufacturer: Texas Instruments; Assembly Type: SMT Assembly; Component Kind: Standard; LCSC Part Number: C473396; Library Name: Dev Board STM OLED 2.0.SCHLIB; Manufacturer Part Number: BQ24078RGTR; Package: VQFN-16_L3.0-W3.0-P0.50-BL-EP
X1 = 1C208000CE0Q: 8Mhz 3225 SMD CRYSTAL RESONATORS 8MHZ SMD-3225-4P ROHS; Manufacturer: KDS Daishinku; Assembly Type: SMT Assembly; Component Kind: Standard; LCSC Part Number: C133366; Library Name: Dev Board STM OLED 2.0.SCHLIB; Manufacturer Part Number: 1C208000CE0Q; Package: SMD-3225_4P
X2 = XTAL_32KHZ_3215: 32.768K SMD CRYSTAL RESONATORS 32.768KHZ ±20PPM SMD-3215_2P ROHS; Manufacturer: Seiko Epson; Manufacturer Part Number: Q13FC1350000400; Assembly Type: SMT Assembly; Component Kind: Standard; LCSC Part Number: C32346; Library Name: Dev Board STM OLED 2.0.SCHLIB; Package: SMD-3215_2P
X3 = X322516MLB4SI: 16MHz SMD Crystal Resonator 9pF ±10ppm ±30ppm -40℃~+85℃ SMD3225-4P Crystals ROHS; Manufacturer: Yangxing Tech; Assembly Type: SMT Assembly; Component Kind: Standard; LCSC Part Number: C13738; Library Name: Dev Board STM OLED 2.0.SchLib; Manufacturer Part Number: X322516MLB4SI; Package: SMD-3225_4P
LO1 = Logo STM32: Description: Logo STM32; Library Name: Dev Board STM OLED 2.0.SchLib
LO2 = LOGO EPCB: Description: LOGO EPCB; Library Name: Dev Board STM OLED 2.0.SchLib
LO3 = Logo Grove Module: Description: Logo Grove Module; Library Name: Dev Board STM OLED 2.0.SchLib
